# Altium schematic sheet Power.SchDoc
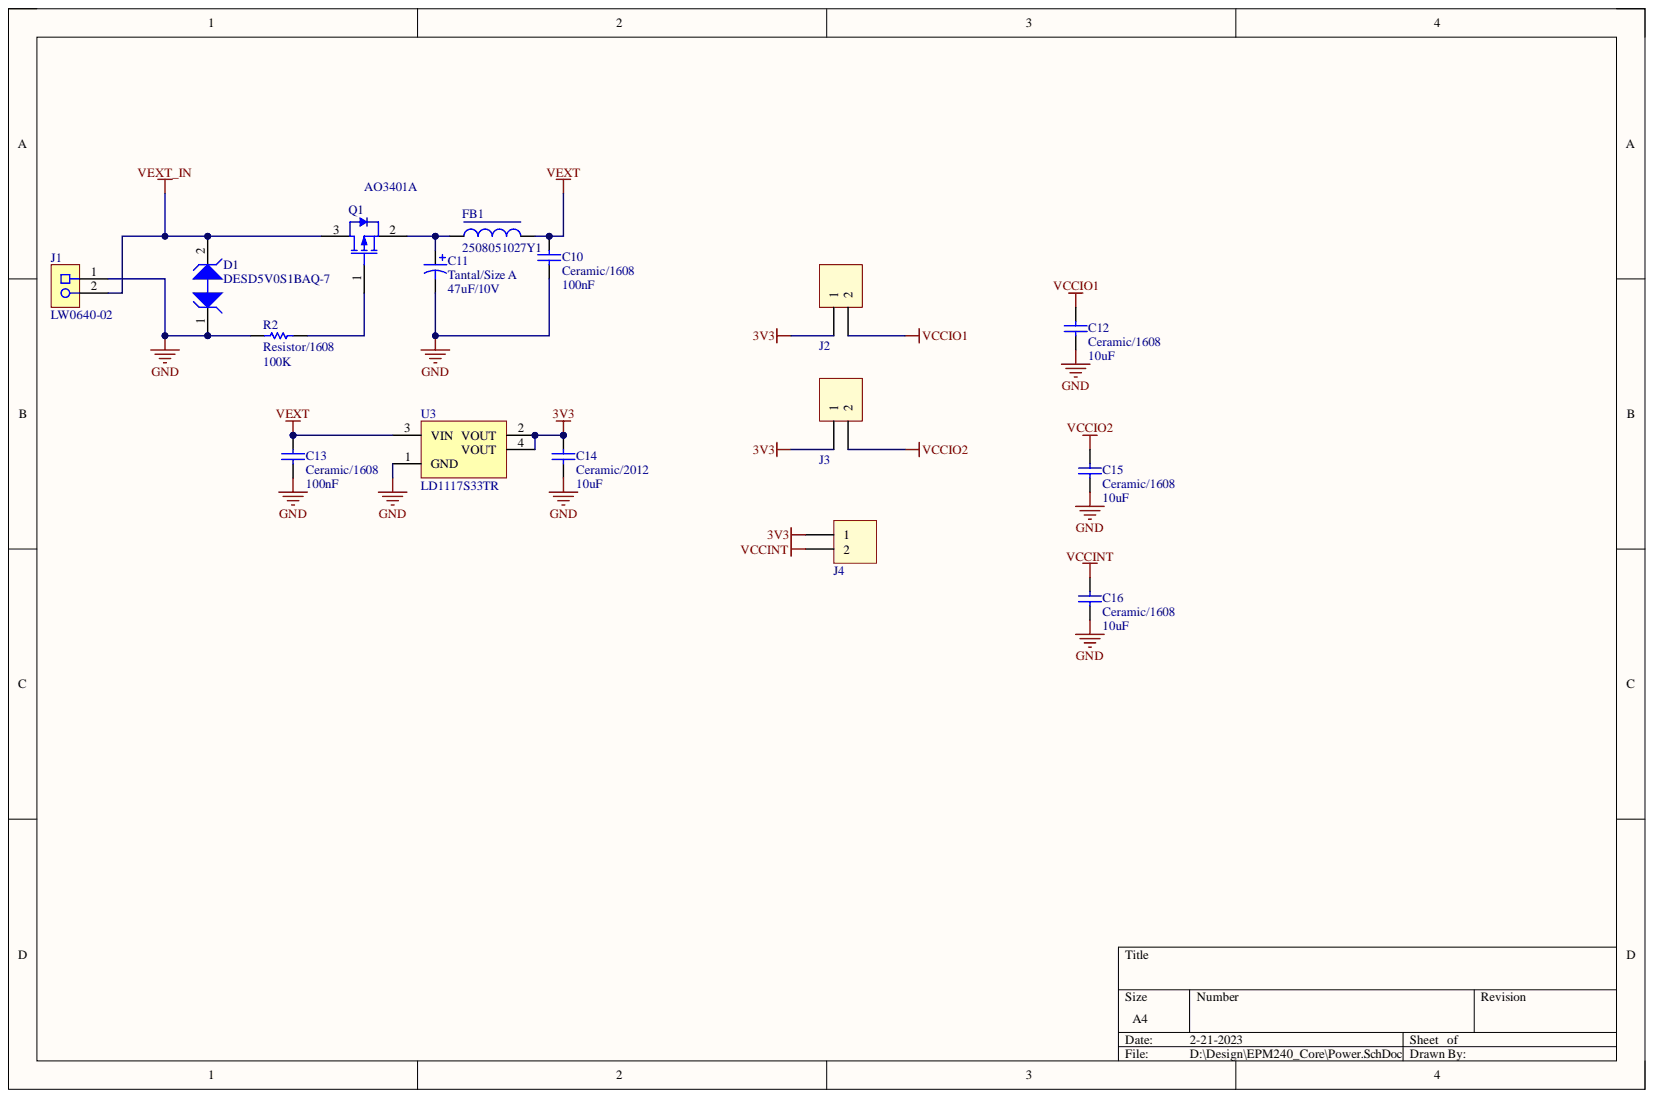
[diagram p0: Power.SchDoc]

## Parts
C10  Ceramic/1608  value 100nF  [Generic 1608 Ceramic]
C11  Tantal/Size A  value 47uF/10V  [Tantal_Size A-Footprint-1]
C12  Ceramic/1608  value 10uF  [Generic 1608 Ceramic]
C13  Ceramic/1608  value 100nF  [Generic 1608 Ceramic]
C14  Ceramic/2012  value 10uF  [Ceramic_2012-Footprint-1]
C15  Ceramic/1608  value 10uF  [Generic 1608 Ceramic]
C16  Ceramic/1608  value 10uF  [Generic 1608 Ceramic]
D1  DESD5V0S1BAQ-7  [SOD323-DIODES]
FB1  2508051027Y1  [2508051027Y1-Footprint-1]
J1  LW0640-02  [LW0640-02]
J2  61300211121  [61300211121]  (value not drawn)
J3  61300211121  [61300211121]  (value not drawn)
J4  61300211121  [61300211121]  (value not drawn)
Q1  AO3401A  [AO-SOT-23-3L_V]
R2  Resistor/1608  value 100K  [Resistor 1608-Footprint]
U3  LD1117S33TR  [SOT-223_L]

## Nets
3V3: C14.2 J2.1 J3.1 J4.1 U3.2(VOUT) U3.4(VOUT)
GND: C10.1 C11.2 C12.1 C13.1 C14.1 C15.1 C16.1 D1.1 J1.1 R2.1 U3.1(GND)
VCCINT: C16.2 J4.2
VCCIO1: C12.2 J2.2
VCCIO2: C15.2 J3.2
VEXT: C10.2 C13.2 FB1.2 U3.3(VIN)
VEXT_IN: D1.2 J1.2 Q1.3
NetC11_1: C11.1 FB1.1 Q1.2
NetQ1_1: Q1.1 R2.2

## Library data of the parts
C10 C12 C13 C15 C16 = CMP-002-0009-3: Generic 1608 Ceramic Capacitor; Capacitor Type: Ceramic; Case/Package: 1608; Component Kind: Standard; Library Name: C6H6`s Vault; Mount: SMD; Pins: 2; RoHS: Yes
C11 = CMP-002-0010-1: Generic Tantal/Size A; Capacitor Type: Tantal; Case/Package: Size A(3216); Component Kind: Standard; Library Name: C6H6`s Vault; Mount: SMD; RoHS: Yes
C14 = CMP-002-0022-1: Generic 2012 Ceramic Capacitor; Capacitor Type: Ceramic; Case/Package: 2012; Component Kind: Standard; Library Name: C6H6`s Vault; Mount: SMD; Pins: 2; RoHS: Yes
D1 = CMP-00792-1: ESD Suppressor Bi-Directional TVS Diode 5.5V 2-Pin SOD-323 T/R; Component Kind: Standard; Component Name: DESD5V0S1BAQ-7; Library Name: C6H6`s Vault; Pin Count: 2
FB1 = CMP-ROOT-0017-1: Ferrite Beads Multi-Layer 1KOhm 25% 100MHz 1A 300mOhm DCR 0805 T/R; DC Resistance (DCR): 300mR; Impedance: 1kR; Case/Package: 2012; Component Kind: Standard; Height: 0.9mm; Length: 2mm; Library Name: C6H6`s Vault; Max DC Current: 1A; Max Operating Temperature: 125°C; Min Operating Temperature: -55°C; Package Quantity: 4000; Packaging: Tape and Reel; Termination: SMD/SMT; Test Frequency: 100MHz; Tolerance: 25%; Width: 1.25mm
J1 = CMP-003-0004-4: 2-pin Molex 5045 compatible Hanlim Connector; Component Kind: Standard; Library Name: C6H6`s Vault; Pin Count: 2
J2-J4 = CMP-005-0052-1: Pin Header WR-PHD, pitch 2.54 mm, THT, Vertical, single row, 2 pin; Contact Resistance: 20 mOhm; Insulation Resistance: >1000 MOhm; Pin Number: 2; Pitch: 2.54 mm; Rated Current: 3 A; Working Voltage: 250 VAC; Component Kind: Standard; Component Name: 61300211121; ComponentLink1Description: Manufacturer; ComponentLink1URL: http://www.we-online.de; ComponentLink2Description: Datasheet; ComponentLink2URL: http://katalog.we-online.de/em/datasheet/6130xx11121.pdf; Designator: 61300211121; Library Name: C6H6`s Vault; Mounting Technology: THT; Operating Temperature: -40 to 105 degC; Orientation: Vertical; Part Number: 61300211121; Pin Count: 2; Published Date: Jan. 2018; Row Number: Single; Publisher: Wurth Elektronik
Q1 = CMP-014-0043-2: MOSFET P-CH 30V 4A SOT23; Input Capacitance: 1.2nF; Max Power Dissipation: 1.4W; Number of Elements: 1; Rds On Max: 44mR; Case/Package: TO-236-3; Component Kind: Standard; Continuous Drain Current (ID): 4A; Drain to Source Voltage (Vdss): 30V; Lead Free: Lead Free; Library Name: C6H6`s Vault; Max Operating Temperature: 150°C; Min Operating Temperature: -55°C; Mount: Surface Mount; Number of Pins: 3; Packaging: Tape and Reel; Power Dissipation: 1.4W; Radiation Hardening: No; Series: -
R2 = CMP-001-0018-2: Generic 1608 Resistor; Case/Package: Bulk; Component Kind: Standard; Library Name: C6H6`s Vault; Mount: SMD; Pins: 2; RoHS: Yes
U3 = CMP-ROOT-0012-1: STMICROELECTRONICS         LD1117S33TR            Fixed LDO Voltage Regulator, 4.75V to 15V, 1.1V Dropout, 3.3Vout, 800mAout, SOT-223-3; Accuracy: 1%; Input Bias Current: 5mA; Max Output Current: 1.3A; Max Output Voltage: 3.3V; Max Power Dissipation: 12W; Number of Outputs: 1; Output Current: 800mA; Polarity: Positive; Quiescent Current: 10mA; Case/Package: SOT-223; Component Kind: Standard; Contact Plating: Tin, Matte; Dropout Voltage: 1.1V; Height: 1.8mm; Lead Free: Lead Free; Length: 6.5mm; Library Name: C6H6`s Vault; Max Input Voltage: 15V; Max Operating Temperature: 125°C; Max Supply Voltage: 15V; Min Input Voltage: 4.75V; Min Operating Temperature: 0°C; Min Output Voltage: 3.3V; Min Supply Voltage: 4.75V; Mount: Surface Mount; Nominal Output Voltage: 3.3V; Number of Pins: 4; Number of Regulators: 1; Output Voltage: 3.3V; Output Voltage Accuracy: 1%; Package Quantity: 1; Packaging: Tape and Reel; Power Dissipation: 12W; Radiation Hardening: No; REACH SVHC: No SVHC; Termination: SMD/SMT; Voltage: 3.3V; Weight: 0.01lb; Width: 3.5mm
